# Altium schematic sheet I2C.SchDoc
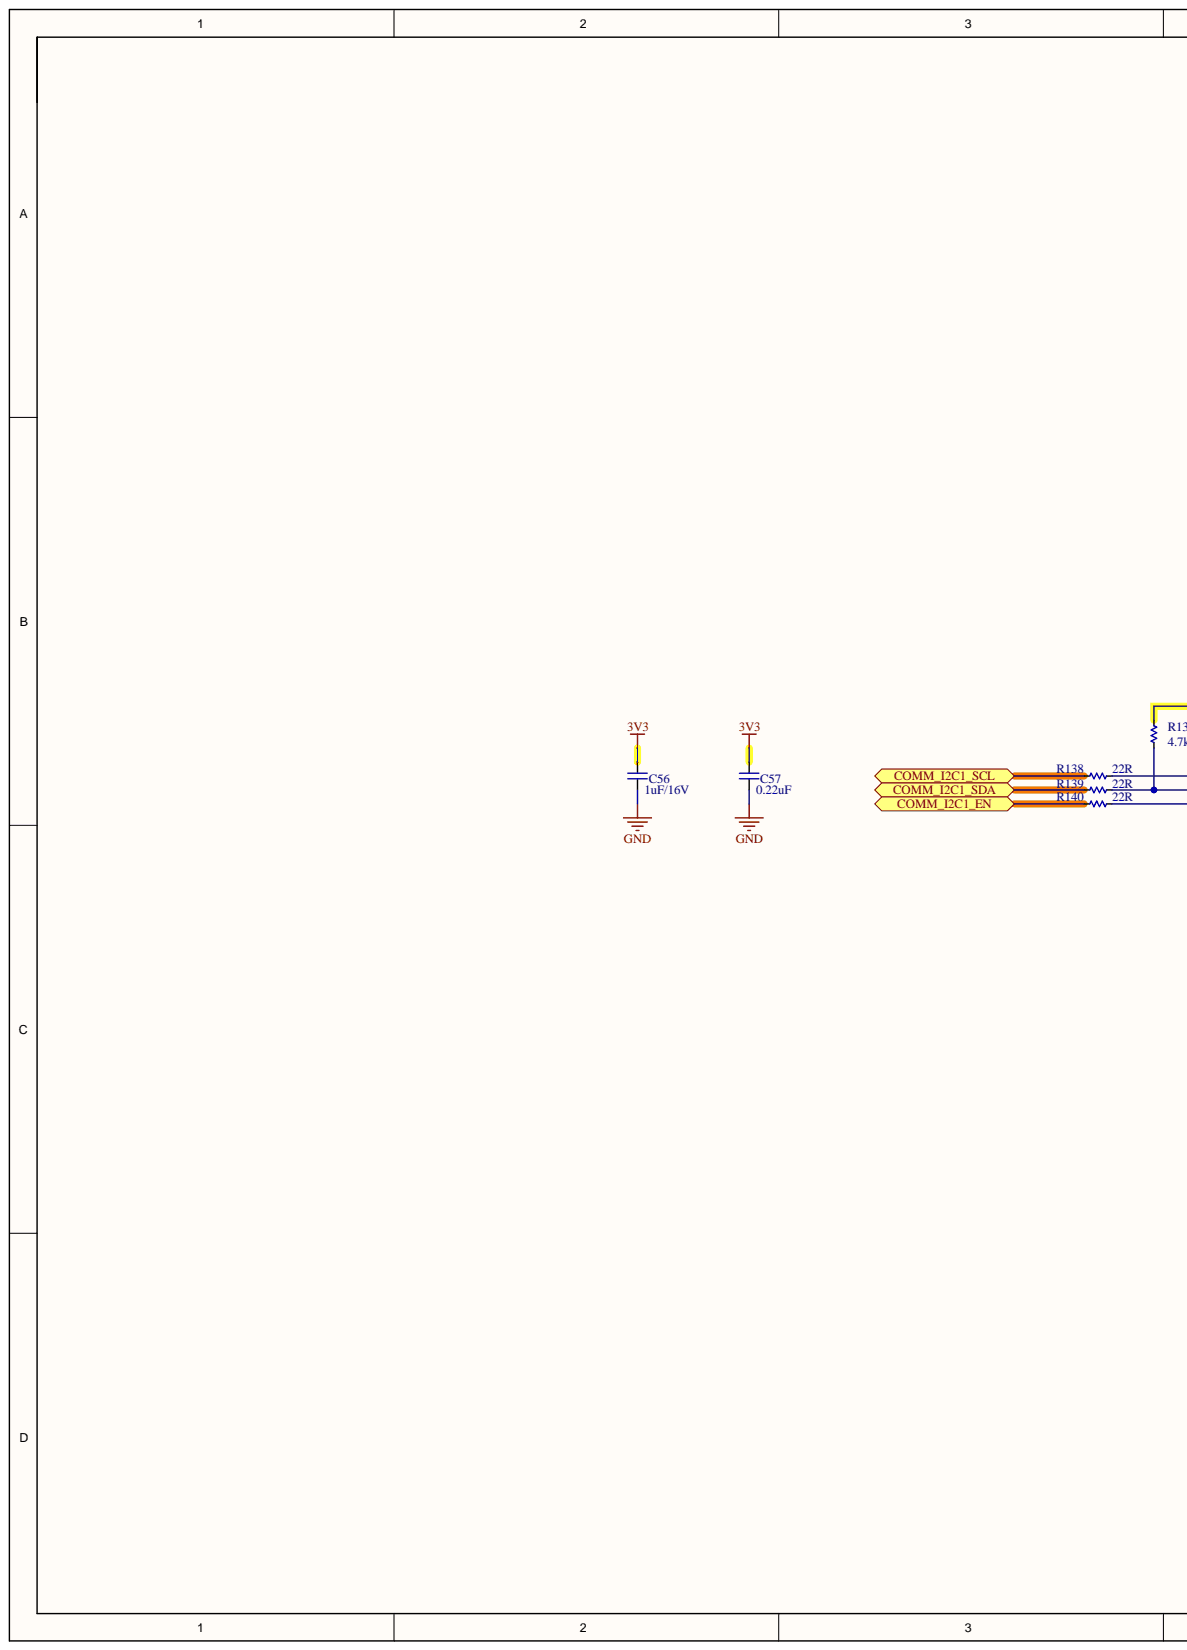
[diagram p0: I2C.SchDoc, left]
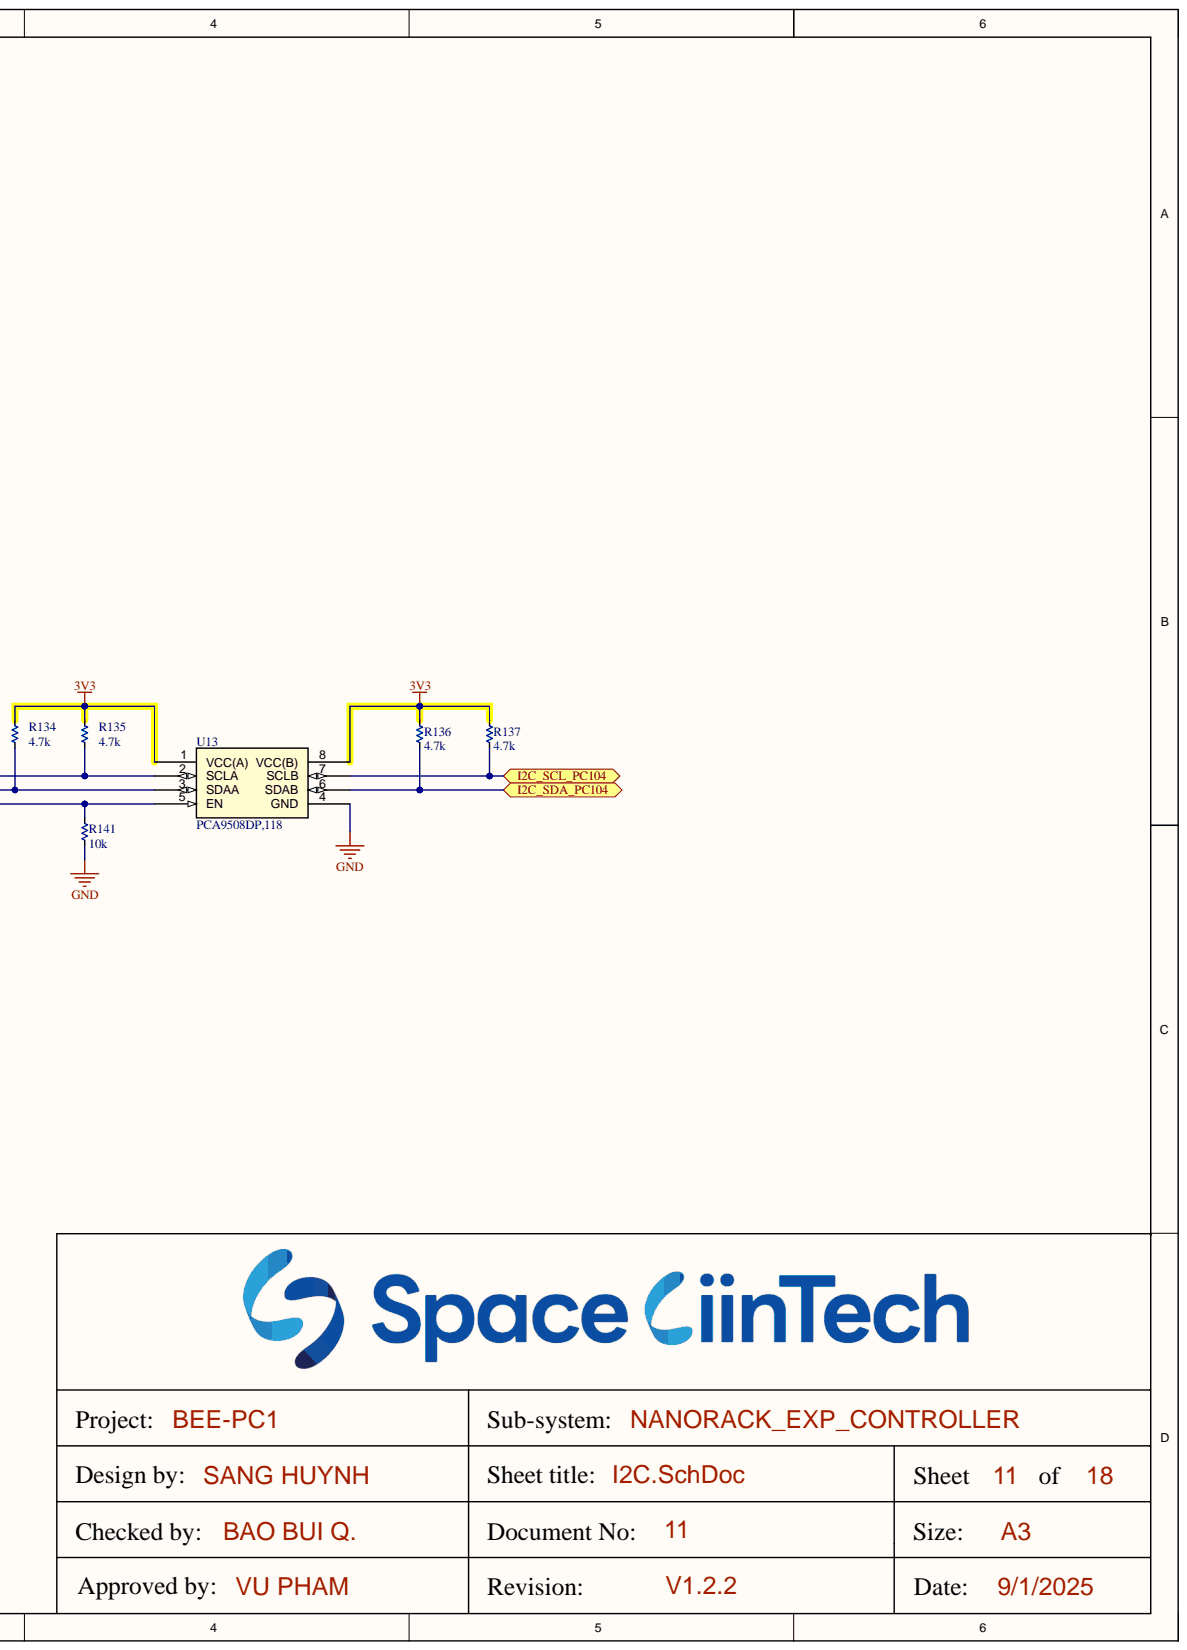
[diagram p1: I2C.SchDoc, right]

## Parts
C56  1uF/16V  [CAP_C_1608X80]
C57  0.22uF  [CAP_C_1608X80]
R134  4.7k  [RES_C_1608X60]
R135  4.7k  [RES_C_1608X60]
R136  4.7k  [RES_C_1608X60]
R137  4.7k  [RES_C_1608X60]
R138  22R  [RES_C_1608X60]
R139  22R  [RES_C_1608X60]
R140  22R  [RES_C_1608X60]
R141  10k  [RES_C_1608X60]
U13  PCA9508DP,118  [TSSOP8_SOT505-1_NXP]

## Ports
COMM_I2C1_EN, COMM_I2C1_SCL, COMM_I2C1_SDA, I2C_SCL_PC104, I2C_SDA_PC104

## Nets
3V3: C56.2 C57.2 R134.1 R135.1 R136.1 R137.1 U13.1(VCC(A)) U13.8(VCC(B))
GND: C56.1 C57.1 R141.2 U13.4(GND)
COMM_I2C1_EN: R140.2 ; port COMM_I2C1_EN
COMM_I2C1_SCL: R138.2 ; port COMM_I2C1_SCL
COMM_I2C1_SDA: R139.2 ; port COMM_I2C1_SDA
I2C_SCL_PC104: R137.2 U13.7(SCLB) ; port I2C_SCL_PC104
I2C_SDA_PC104: R136.2 U13.6(SDAB) ; port I2C_SDA_PC104
NetR134_2: R134.2 R139.1 U13.3(SDAA)
NetR135_2: R135.2 R138.1 U13.2(SCLA)
NetR140_1: R140.1 R141.1 U13.5(EN)

## Library data of the parts
C56 C57 = CAP_SMD: CAP_Chip; Value: 33pF; Component Kind: Standard; Library Name: LABLIB.SchLib
R134-R141 = _RES_B_2: RES; Component Kind: Standard; Library Name: LABLIB.SchLib
U13 = PCA9508DP,118: No Description Available; Manufacturer_Name: NXP; Component Kind: Standard; Manufacturer_Part_Number: PCA9508DP,118; RefDes: RefDes; Type: Type; Copyright: Copyright (C) 2025 Ultra Librarian. All rights reserved.; Library Name: COMM_SCHLIB.SchLib
